# Altium schematic sheet MCED_Controller.SchDoc(MCED_Controller)
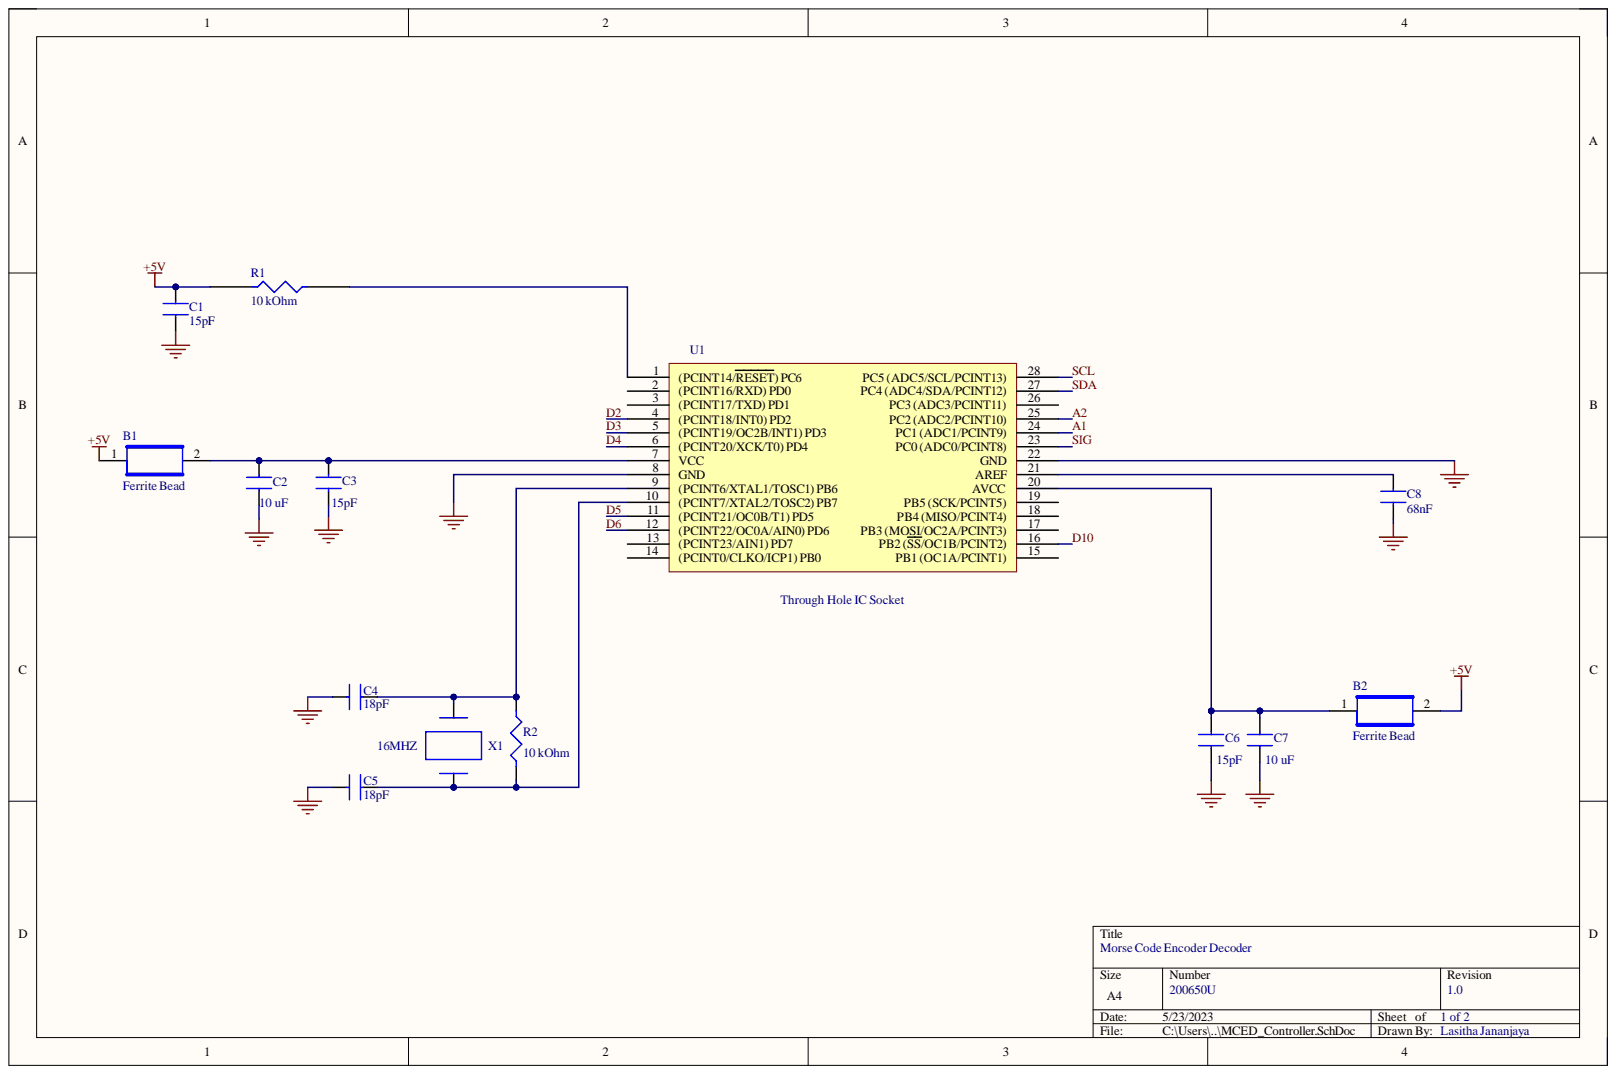
[diagram p0: MCED_Controller.SchDoc]

## Parts
B1  Ferrite Bead  Material: Ferrite  [FERRITE BEAD C2012X105N]
B2  Ferrite Bead  Material: Ferrite  [FERRITE BEAD C2012X105N]
C1  15pF  [C0805]
C2  10 uF  [C0805]
C3  15pF  [C0805]
C4  18pF  [C0805]
C5  18pF  [C0805]
C6  15pF  [C0805]
C7  10 uF  [C0805]
C8  68nF  [C0805]
R1  10 kOhm  [10K]
R2  10 kOhm  [10K]
U1  Through Hole IC Socket  [28dip_through_hole_ic_socket]
X1  16MHZ  [ABLS-16.000MHZ-B4-T_CRYSTAL]

## Nets
+5V: B1.1 B2.2 C1.2 R1.2
GND: C1.1 C2.1 C3.1 C4.2 C5.2 C6.1 C7.1 C8.1 U1.8(GND) U1.22(GND)
A1: U1.24(PC1_(ADC1/PCINT9))
A2: U1.25(PC2_(ADC2/PCINT10))
D2: U1.4((PCINT18/INT0)_PD2)
D3: U1.5((PCINT19/OC2B/INT1)_PD3)
D4: U1.6((PCINT20/XCK/T0)_PD4)
D5: U1.11((PCINT21/OC0B/T1)_PD5)
D6: U1.12((PCINT22/OC0A/AIN0)_PD6)
D10: U1.16(PB2_(SS/OC1B/PCINT2))
SCL: U1.28(PC5_(ADC5/SCL/PCINT13))
SDA: U1.27(PC4_(ADC4/SDA/PCINT12))
SIG: U1.23(PC0_(ADC0/PCINT8))
NetB1_2: B1.2 C2.2 C3.2 U1.7(VCC)
NetB2_1: B2.1 C6.2 C7.2 U1.20(AVCC)
NetC4_1: C4.1 R2.2 U1.9((PCINT6/XTAL1/TOSC1)_PB6) X1.2
NetC5_1: C5.1 R2.1 U1.10((PCINT7/XTAL2/TOSC2)_PB7) X1.1
NetC8_2: C8.2 U1.21(AREF)
NetR1_1: R1.1 U1.1((PCINT14/RESET)_PC6)

Not connected: U1 2((PCINT16/RXD)_PD0) 3((PCINT17/TXD)_PD1) 13((PCINT23/AIN1)_PD7) 14((PCINT0/CLKO/ICP1)_PB0) 15(PB1_(OC1A/PCINT1)) 17(PB3_(MOSI/OC2A/PCINT3)) 18(PB4_(MISO/PCINT4)) 19(PB5_(SCK/PCINT5)) 26(PC3_(ADC3/PCINT11))

## Library data of the parts
B1 B2 = MPZ2012S601AT000: Ferrite Bead, 1 Function(s), 2A; Manufacturer: TDK; Channels: 1; Clock Frequency: 100MHz; DC Resistance-Max: 100mR; Filter Type: FERRITE CHIP; Output Impedance: 600R; Case Code: 0805; Category: Ferrite Beads and Chips; Component Kind: Standard; ComponentLink1Description: https://datasheet.ciiva.com:443/pdfs/VipMasterIC/IC/TDKC/TDKC-S-A0008032886/TDKC-S-A0008032886-1.pdf?src-supplier=IHS+Markit; ComponentLink1URL: https://datasheet.ciiva.com:443/pdfs/VipMasterIC/IC/TDKC/TDKC-S-A0008032886/TDKC-S-A0008032886-1.pdf?src-supplier=IHS+Markit; ComponentLink2Description: https://datasheet.ciiva.com/46634/beads-commercial-power-mpz-en-46634335.pdf?src-supplier=Digi-Key; ComponentLink2URL: https://datasheet.ciiva.com/46634/beads-commercial-power-mpz-en-46634335.pdf?src-supplier=Digi-Key; ComponentLink3Description: https://datasheet.ciiva.com/46634/beads-commercial-power-mpz-en-46634377.pdf?src-supplier=Digi-Key; ComponentLink3URL: https://datasheet.ciiva.com/46634/beads-commercial-power-mpz-en-46634377.pdf?src-supplier=Digi-Key; ComponentLink4Description: https://datasheet.ciiva.com/46634/beads-commercial-power-mpz-en-46634606.pdf?src-supplier=Digi-Key; ComponentLink4URL: https://datasheet.ciiva.com/46634/beads-commercial-power-mpz-en-46634606.pdf?src-supplier=Digi-Key; Construction: Chip Bead; Current Rating: 2A; Height: 0.85mm; JESD-609 Code: e2; Length: 2mm; Manufacturer Part Number: MPZ2012S601AT000; Max Operating Temperature: 125°C; Min Frequency: 100MHz; Min Operating Temperature: -55°C; Mounting Technology: Surface Mount; Packaging: Tape and Reel; Pricing: 1=0.12, 10=0.061, 50=0.061, 100=0.042, 1000=0.035, 10000=0.027 (USD); Reach SVHC Compliant: Yes; RoHS: Yes; RoHS Compliant: Yes; Stock: 782263; Supplier: Mouser; Supplier Part Number: 810-MPZ2012S601AT000; Terminal Finish: Copper; Width: 1.25mm; Library Name: MCED_Schlib.SchLib
C1 C3-C6 = VJ0805A220GXACW1BC: 22pF Ceramic Capacitor, Multilayer, Ceramic, 50V, 2% +Tol, 2% -Tol, C0G, -/+30ppm/Cel TC, 0.000022uF, Surface Mount, 0805; Manufacturer: Vishay Vitramon; Manufacturer Series: VJ; Multilayer: Yes; Capacitor Type: Ceramic; Case/Package: 0805; Category: Ceramic Capacitors; Component Kind: Standard; ComponentLink1Description: https://datasheet.ciiva.com:443/pdfs/VipMasterIC/IC/BEYS/BEYSS01615/BEYSS01615-1.pdf?src-supplier=IHS+Markit; ComponentLink1URL: https://datasheet.ciiva.com:443/pdfs/VipMasterIC/IC/BEYS/BEYSS01615/BEYSS01615-1.pdf?src-supplier=IHS+Markit; ComponentLink2Description: https://datasheet.ciiva.com/16511/vjw1bcbascomseries-16511287.pdf?src-supplier=Future+Electronics; ComponentLink2URL: https://datasheet.ciiva.com/16511/vjw1bcbascomseries-16511287.pdf?src-supplier=Future+Electronics; Dielectric Material: Ceramic; JESD-609 Code: e3; Manufacturer Part Number: VJ0805A220GXACW1BC; Max Operating Temperature: 125°C; Min Operating Temperature: -55°C; Mounting Technology: Surface Mount; Package Shape: Rectangular; Packaging: Tape and Reel; Pins: 2; Pricing: 5=0.0755, 50=0.0623, 150=0.0557, 500=0.0508, 2500=0.042, 4000=0.04 (USD); Rated DC Voltage (URdc): 50V; Reach SVHC Compliant: Yes; RoHS: Yes; RoHS Compliant: Yes; Stock: 3750; Supplier: LCSC; Supplier Part Number: C2174318; Temperature Characteristics Code: C0G; Terminal Finish: Tin; Termination: Wraparound; Tolerance  (fill in as +-): 2%; Tolerance (fill in as +-): 2%; Value: 22pF; Library Name: MCED_Schlib.SchLib
C2 C7 C8 = CL21A106KBYQNNE: 10 uF Ceramic Capacitor, Multilayer, Ceramic, 50V, 10% +Tol, 10% -Tol, X5R, 15% TC, 10uF, Surface Mount, 0805; Manufacturer: Samsung; Capacitor Type: Ceramic; Category: Ceramic Capacitors; Component Kind: Standard; ComponentLink1Description: https://datasheet.ciiva.com:443/pdfs/VipMasterIC/IC/SEMS/SEMS-S-A0008524728/SEMS-S-A0008519922-1.pdf?src-supplier=IHS+Markit; ComponentLink1URL: https://datasheet.ciiva.com:443/pdfs/VipMasterIC/IC/SEMS/SEMS-S-A0008524728/SEMS-S-A0008519922-1.pdf?src-supplier=IHS+Markit; Dielectric Material: Ceramic; Manufacturer Part Number: CL21A106KBYQNNE; Pins: 2; Pricing: 1=0.42, 10=0.299, 50=0.299, 100=0.197, 1000=0.124, 10000=0.1 (USD); Reach SVHC Compliant: Yes; RoHS: Yes; RoHS Compliant: Yes; Stock: 29602; Supplier: Mouser; Supplier Part Number: 187-CL21A106KBYQNNE; Library Name: MCED_Schlib.SchLib
R1 R2 = MCU0805MD1002DP500: 10 kOhm, Fixed Resistor, Thin Film, 0.2W, 10000ohm, 150V, 0.5% +/-Tol, -25,25ppm/Cel, 0805; Manufacturer: Vishay Beyschlag; Package Height: 0.52mm; Package Length: 2mm; Package Width: 1.25mm; Power: 200mW; Reference Standard: AEC-Q200; Temperature Coefficient: -2525ppm/°C; Value: 10kR; Case/Package: 0805; Category: Chip SMD Resistors; Component Kind: Standard; ComponentLink1Description: https://datasheet.ciiva.com:443/pdfs/VipMasterIC/IC/VISH/VISH-S-A0002932058/VISH-S-A0002932058-1.pdf?src-supplier=IHS+Markit; ComponentLink1URL: https://datasheet.ciiva.com:443/pdfs/VipMasterIC/IC/VISH/VISH-S-A0002932058/VISH-S-A0002932058-1.pdf?src-supplier=IHS+Markit; ComponentLink2Description: https://datasheet.ciiva.com/12696/mcat-pro-223602-12696124.pdf?src-supplier=Mouser; ComponentLink2URL: https://datasheet.ciiva.com/12696/mcat-pro-223602-12696124.pdf?src-supplier=Mouser; Construction: Chip; JESD-609 Code: e3; Manufacturer Part Number: MCU0805MD1002DP500; Max Operating Temperature: 175°C; Min Operating Temperature: -55°C; Package Style: SMTMeter; Packaging: Tape and Reel; Pins: 2; Pricing: 1=0.39, 10=0.3, 50=0.3, 100=0.127, 1000=0.07, 10000=0.06 (USD); Reach SVHC Compliant: Yes; Resistor Type: FIXED RESISTOR; RoHS: Yes; RoHS Compliant: Yes; Series: MCU 0805 AT-PROF; Stock: 49020; Supplier: Mouser; Supplier Part Number: 594-MCU0805MD1002DP5; Technology: THIN FILM; Terminal Finish: Nickel; Tolerance: 0.5%; Working Voltage: 150V; Library Name: MCED_Schlib.SchLib
U1 = ICS-328-T: Through Hole IC Sockets 28P / .300"; Manufacturer: Adam Equipment; Category: IC and Component Sockets; Component Kind: Standard; ComponentLink1Description: https://datasheet.ciiva.com/16210/page-187-188-16210500.pdf?src-supplier=Future+Electronics; ComponentLink1URL: https://datasheet.ciiva.com/16210/page-187-188-16210500.pdf?src-supplier=Future+Electronics; Manufacturer Part Number: ICS-328-T; Pricing: 1=0.1941, 17=0.1922, 34=0.1565, 51=0.1549, 85=0.1534, 238=0.139, 442=0.1333, 952=0.1182 (USD); RoHS: Yes; Stock: 603; Supplier: Arrow Electronics; Supplier Part Number: ICS-328-T; Library Name: MCED_Schlib.SchLib
X1 = ABLS-16.000MHZ-B4-T: 16MHz ±30ppm 晶体 18pF 40 欧姆 -20°C ~ 70°C  表面贴装 HC49/US; Manufacturer: Abracon; Category: Crystals; Component Kind: Standard; ComponentLink1Description: https://datasheet.ciiva.com/15116/getdatasheetpartid-719935-15116811.pdf?src-supplier=Verical; ComponentLink1URL: https://datasheet.ciiva.com/15116/getdatasheetpartid-719935-15116811.pdf?src-supplier=Verical; ComponentLink2Description: https://datasheet.ciiva.com/854/abls-854145.pdf?src-supplier=Digi-Key; ComponentLink2URL: https://datasheet.ciiva.com/854/abls-854145.pdf?src-supplier=Digi-Key; ComponentLink3Description: https://datasheet.ciiva.com/854/abls-854144.pdf?src-supplier=Digi-Key; ComponentLink3URL: https://datasheet.ciiva.com/854/abls-854144.pdf?src-supplier=Digi-Key; ComponentLink4Description: https://datasheet.ciiva.com/854/abls-854146.pdf?src-supplier=Digi-Key; ComponentLink4URL: https://datasheet.ciiva.com/854/abls-854146.pdf?src-supplier=Digi-Key; ComponentLink5Description: https://datasheet.ciiva.com/3175/abls-3175359.pdf?src-supplier=Avnet; ComponentLink5URL: https://datasheet.ciiva.com/3175/abls-3175359.pdf?src-supplier=Avnet; ComponentLink6Description: https://datasheet.ciiva.com/16423/abls-16423759.pdf?src-supplier=Future+Electronics; ComponentLink6URL: https://datasheet.ciiva.com/16423/abls-16423759.pdf?src-supplier=Future+Electronics; Manufacturer Part Number: ABLS-16.000MHZ-B4-T; Pricing: 1=0.2737, 10=0.1984, 30=0.1552, 100=0.136, 500=0.1275, 1000=0.1224 (USD); RoHS: Yes; Stock: 196; Supplier: LCSC; Supplier Part Number: C596821; Library Name: MCED_Schlib.SchLib
